# Altium schematic sheet 09_SHARED_PERIPHERAL.SchDoc(09_SHARED_PERIPHERAL)
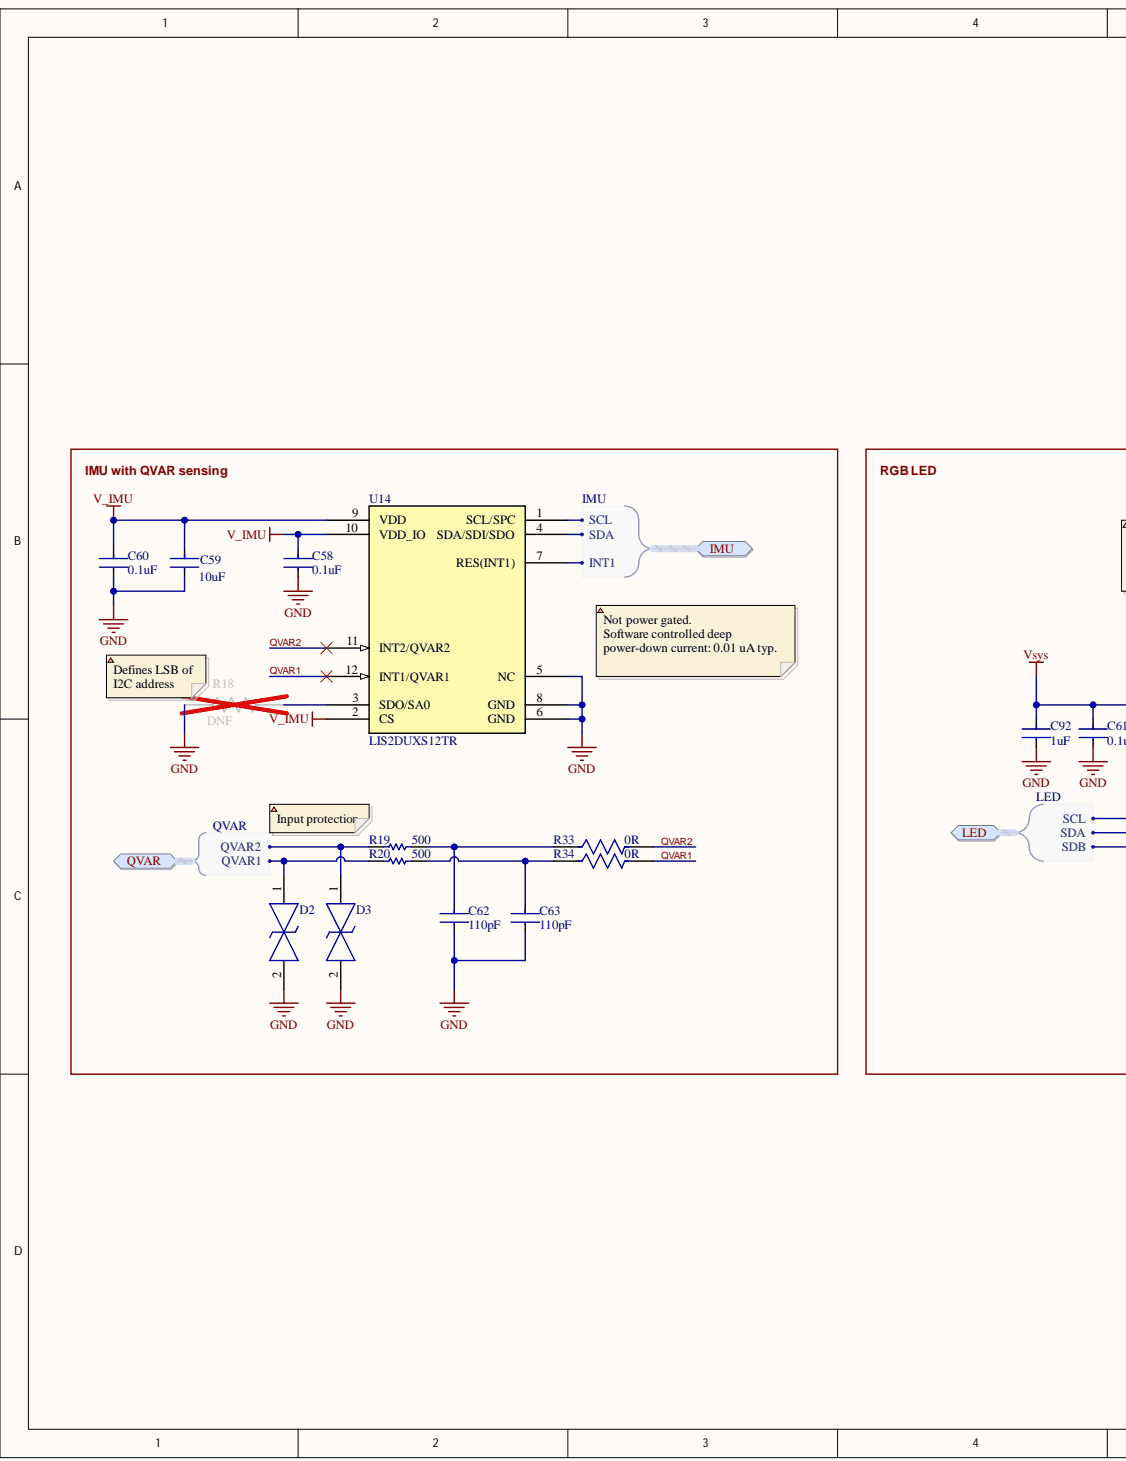
[diagram p0: 09_SHARED_PERIPHERAL.SchDoc, left]
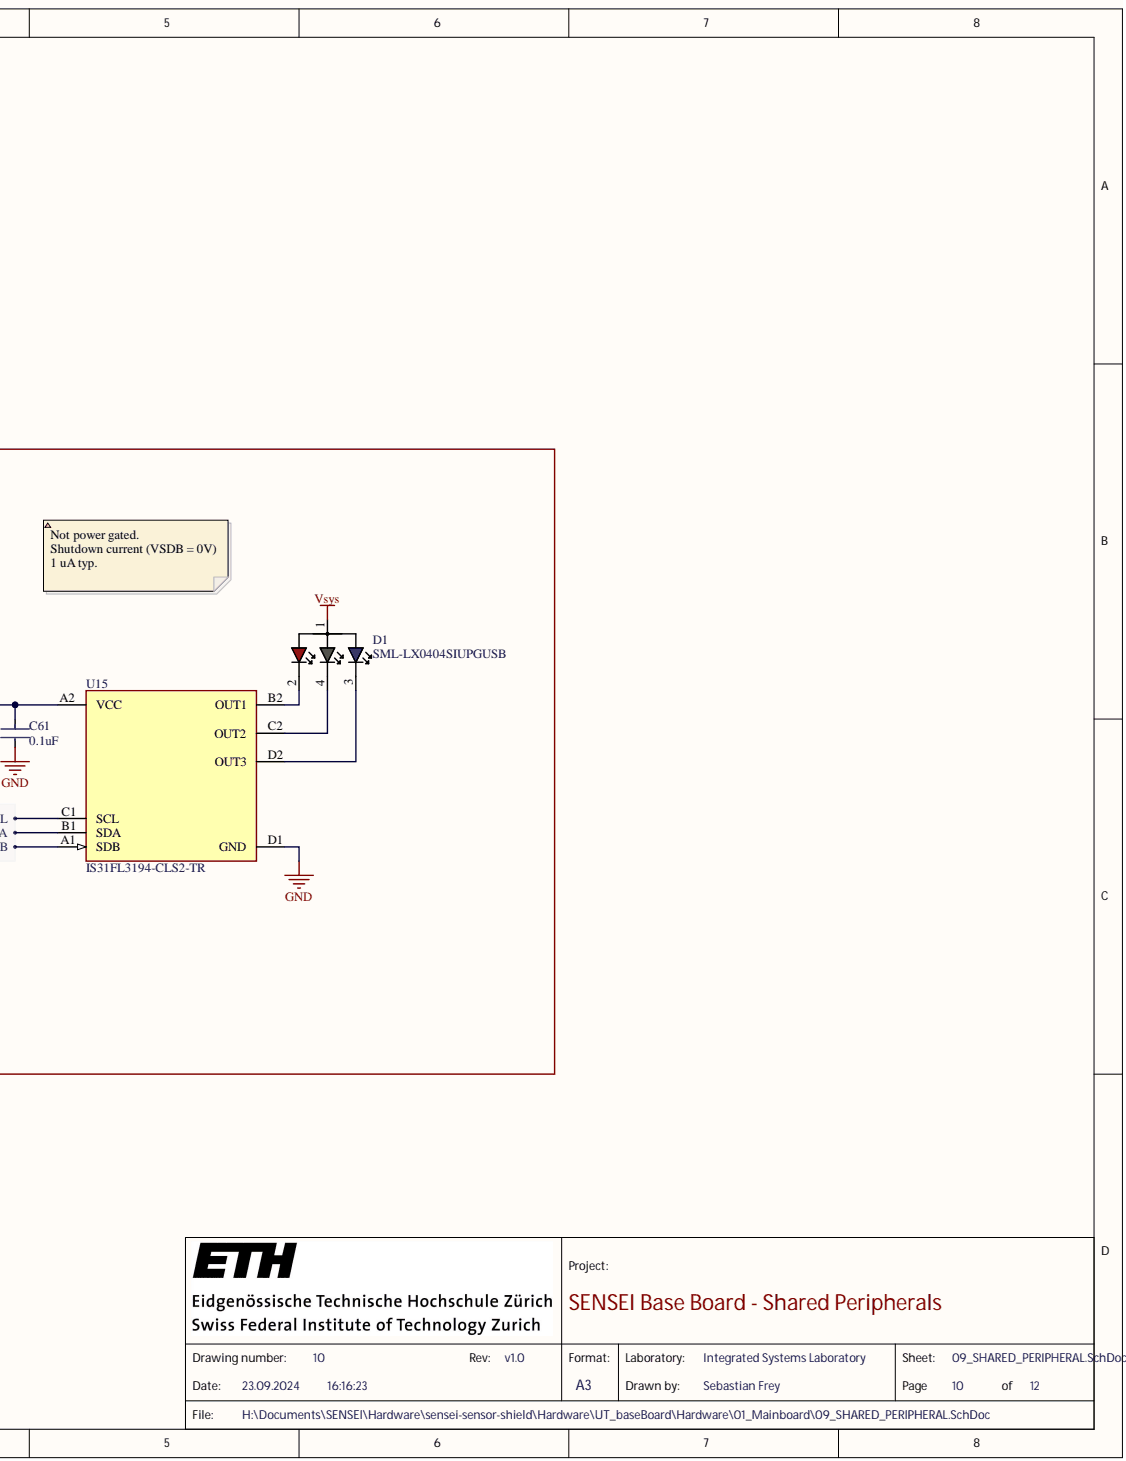
[diagram p1: 09_SHARED_PERIPHERAL.SchDoc, right]

## Parts
C58  Capacitance: 0.1uF  [FP-GRM033-0_03-IPC_B]  (hidden comment: GRM033Z71C104KE14D)
C59  value 10uF  Capacitance: 10uF  [FP-CL05A106MP5NUNC-IPC_B]  (hidden comment: CL05A106MP5NUNC)
C60  Capacitance: 0.1uF  [FP-GRM033-0_03-IPC_B]  (hidden comment: GRM033Z71C104KE14D)
C61  Capacitance: 0.1uF  [FP-GRM033-0_03-IPC_B]  (hidden comment: GRM033Z71C104KE14D)
C62  Capacitance: 110pF  [FP-GRM033-0_03-IPC_C]  (hidden comment: GRM0335C1H111JA01D)
C63  Capacitance: 110pF  [FP-GRM033-0_03-IPC_C]  (hidden comment: GRM0335C1H111JA01D)
C92  Capacitance: 1uF  [FP-CL03-IPC_B]  (hidden comment: CL03A105KP3NSNC)
D1  SML-LX0404SIUPGUSB  [SML-LX0404SIUPGUSB]
D2  TPD1E01B04DPYRQ1  [TPD1E01B04DPYRQ1]  (value not drawn)
D3  TPD1E01B04DPYRQ1  [TPD1E01B04DPYRQ1]  (value not drawn)
R18  DNF  [FP-RMCF0201-IPC_C]
R19  ERJ-1GNF4990C  [RESC0603X26X15LL03T05]  (value not drawn)
R20  ERJ-1GNF4990C  [RESC0603X26X15LL03T05]  (value not drawn)
R33  Resistance: 0R  [FP-RMCF0402-IPC_A]  (hidden comment: RMCF0402ZT0R00)
R34  Resistance: 0R  [FP-RMCF0402-IPC_A]  (hidden comment: RMCF0402ZT0R00)
U14  LIS2DUXS12TR  [QFN_DUXS12_STM]
U15  IS31FL3194-CLS2-TR  [WLCSP_8_P0.4]

## Ports
IMU, IMU.INT1, IMU.SCL, IMU.SDA, LED, LED.SCL, LED.SDA, LED.SDB, QVAR, QVAR.QVAR1, QVAR.QVAR2

## Nets
GND: C58.2 C59.2 C60.2 C61.2 C62.2 C63.2 C92.2 D2.2 D3.2 R18.1 U14.5(NC) U14.6(GND) U14.8(GND) U15.D1(GND)
V_IMU: C58.1 C59.1 C60.1 U14.2(CS) U14.9(VDD) U14.10(VDD_IO)
Vsys: C61.1 C92.1 D1.1 U15.A2(VCC)
QVAR1: R34.2 U14.12(INT1/QVAR1)
QVAR2: R33.2 U14.11(INT2/QVAR2)
NetC62_1: C62.1 R19.2 R33.1
NetC63_1: C63.1 R20.2 R34.1
NetD1_2: D1.2 U15.B2(OUT1)
NetD1_3: D1.3 U15.D2(OUT3)
NetD1_4: D1.4 U15.C2(OUT2)
NetD2_1: D2.1 R20.1 ; port QVAR
NetD3_1: D3.1 R19.1 ; port QVAR
NetR18_2: R18.2 U14.3(SDO/SA0)
NetU14_1: U14.1(SCL/SPC) ; port IMU
NetU14_4: U14.4(SDA/SDI/SDO) ; port IMU
NetU14_7: U14.7(RES(INT1)) ; port IMU
NetU15_A1: U15.A1(SDB) ; port LED
NetU15_B1: U15.B1(SDA) ; port LED
NetU15_C1: U15.C1(SCL) ; port LED

## Library data of the parts
C58 C60 C61 = CMP-06035-035508-1: Chip Multilayer Ceramic Capacitors for General Purpose, 0201, 0.10uF, X7R, 15%, 10%, 16V; Case/Package: 0201; Component Kind: Standard; Library Name: Altium Content Vault
C59 = CMP-13271-000002-1: CAP CER 10UF 10V X5R 0402; Manufacturer: Samsung; Material: Ceramic; Temperature Coefficient: 15%; Tolerance: 20%; Automotive: No; Category: Cap; Component Kind: Standard; ComponentLink2Description: Datasheet; ComponentLink2URL: http://www.samsungsem.com/kr/support/product-search/mlcc/__icsFiles/afieldfile/2019/05/10/19-CL05A106MP5NUNC.pdf; Device Class L1: Passive Components; Device Class L2: Capacitors; Device Class L3: Ceramic Capacitors; Digikey Description: CAP CER 10UF 10V X5R 0402; Height: 0.7mm; Ipc Land Pattern Name: CAPC10050X50; Lead Free: Yes; Library Name: Altium Content Vault; Manufacturer Part Number: CL05A106MP5NUNC; Octopart: https://octopart.com/cl05a106mp5nunc-samsung-22457395; Package: CL05A106MP5NUNC; RoHS: TRUE; Temperature: -55°C to +85°C; Temperature Characteristic: X5R; Temperature Range High: +85°C; Temperature Range Low: -55°C; Voltage Rating: 10V
C62 C63 = CMP-06035-019132-1: Chip Multilayer Ceramic Capacitors for General Purpose, 0201, 110pF, C0G, 30ppm/°C, 5%, 50V; Case Code (Imperial): 0201; Case Code (Metric): 0603; Case/Package: 0201; Component Kind: Standard; Dielectric: C0G; Height: 0.3mm; Length: 0.024inch; Library Name: Altium Content Vault; Max Operating Temperature: 125°C; Min Operating Temperature: -55°C; Mount: Surface Mount; Package Quantity: 1; Packaging: Tape and Reel; Termination: SMD/SMT; Thickness: 0.013inch; Tolerance: 5%; Voltage Rating: 50V; Voltage Rating (DC): 50V; Weight: 1.2E-05oz; Width: 0.3mm
C92 = CMP-13271-003594-1: Cap Ceramic 1uF 10V X5R ±10% Pad SMD 0201 +85°C T/R; Case Code (Imperial): 0201; Case Code (Metric): 0603; Case/Package: 0201; Component Kind: Standard; Length: 0.024inch; Library Name: Altium Content Vault; Max Operating Temperature: 85°C; Min Operating Temperature: -55°C; Mount: Surface Mount; Packaging: Cut Tape; Thickness: 0.013inch; Tolerance: 10%; Voltage Rating: 10V; Width: 0.012inch
D1 = D_RGB_LED: SML-LX0404SIUPGUSB RGB LED; Manufacturer: Lumex; Component Kind: Standard; Library Name: EEG_SchLib.SchLib; Manufacturer Part Number: SML-LX0404SIUPGUSB; Supplier 1: Mouser; Supplier Part Number 1: 696-LX0404SIUPGUSB
D2 D3 = TPD1E01B04DPYRQ1: TVS Diode (Bi-directional); Manufacturer_Name: Texas Instruments; Arrow Part Number: TPD1E01B04DPYRQ1; Arrow Price/Stock: https://www.arrow.com/en/products/tpd1e01b04dpyrq1/texas-instruments?region=nac; Component Kind: Standard; Datasheet Link: https://www.ti.com/lit/ds/symlink/tpd1e01b04-q1.pdf?ts=1677834076075&ref_url=https%253A%252F%252Fwww.ti.com%252Fproduct%252FTPD1E01B04-Q1%252Fpart-details%252FTPD1E01B04DPYRQ1; Height: 0.45mm; Library Name: EEG_SchLib.SchLib; Manufacturer_Part_Number: TPD1E01B04DPYRQ1; Mouser Part Number: 595-TPD1E01B04DPYRQ1; Mouser Price/Stock: https://www.mouser.co.uk/ProductDetail/Texas-Instruments/TPD1E01B04DPYRQ1?qs=doiCPypUmgEwTnvBpZhkOw%3D%3D
R18 = CMP-26527-001890-1: Component Kind: Standard; Library Name: Altium Content Vault
R19 R20 = CMP-2004-03369-1: Manufacturer: Panasonic; Resistance: 500; Component Kind: Standard; Library Name: Altium Content Vault; Part Number: ERJ-1GNF4990C
R33 R34 = CMP-26527-007617-1: RES 0 OHM JUMPER 1/16W 0402; Current Rating: 1A; Max Power Dissipation: 63mW; Working Voltage: 50V; Case Code (Imperial): 0402; Case Code (Metric): 1005; Case/Package: 0402; Component Kind: Standard; Construction: Rectangular; Contact Plating: Tin; Depth: 0.5mm; Features: Automotive AEC-Q200; Height: 0.016inch; Lead Free: Lead Free; Length: 0.039inch; Library Name: Altium Content Vault; Max Operating Temperature: 155°C; Military Standard: Not; Min Operating Temperature: -55°C; Mount: Surface Mount; Number of Terminations: 2; Packaging: Tape and Reel; Power Rating: 63mW; Radiation Hardening: No; Resistor Type: Thick Film; RoHS Compliant: Yes; Series: RMCF; Technology: THICK FILM; Width: 0.02inch
U14 = LIS2DUXS12TR: No Description Available; Mfr_Name: STMicroelectronics; Component Kind: Standard; Library Name: EEG_SchLib.SchLib; Manufacturer_Part_Number: LIS2DUXS12TR; RefDes: RefDes; Type: DEV; Copyright: Copyright (C) 2023 Ultra Librarian. All rights reserved.
U15 = IS31FL3194: LED Driver with Programmable Pattern Sequencing 3-Channel 40mA 8-Pin WCSP T/R; Manufacturer: ISSI; Component Kind: Standard; Library Name: EEG_SchLib.SchLib; Manufacturer Part Number: IS31FL3194-CLS2-TR; Supplier 1: Digi-Key; Supplier Part Number 1: 2521-IS31FL3194-CLS2-TRCT-ND
